# Altium schematic sheet Proximity_Sensors.SchDoc(Proximity_Sensors)
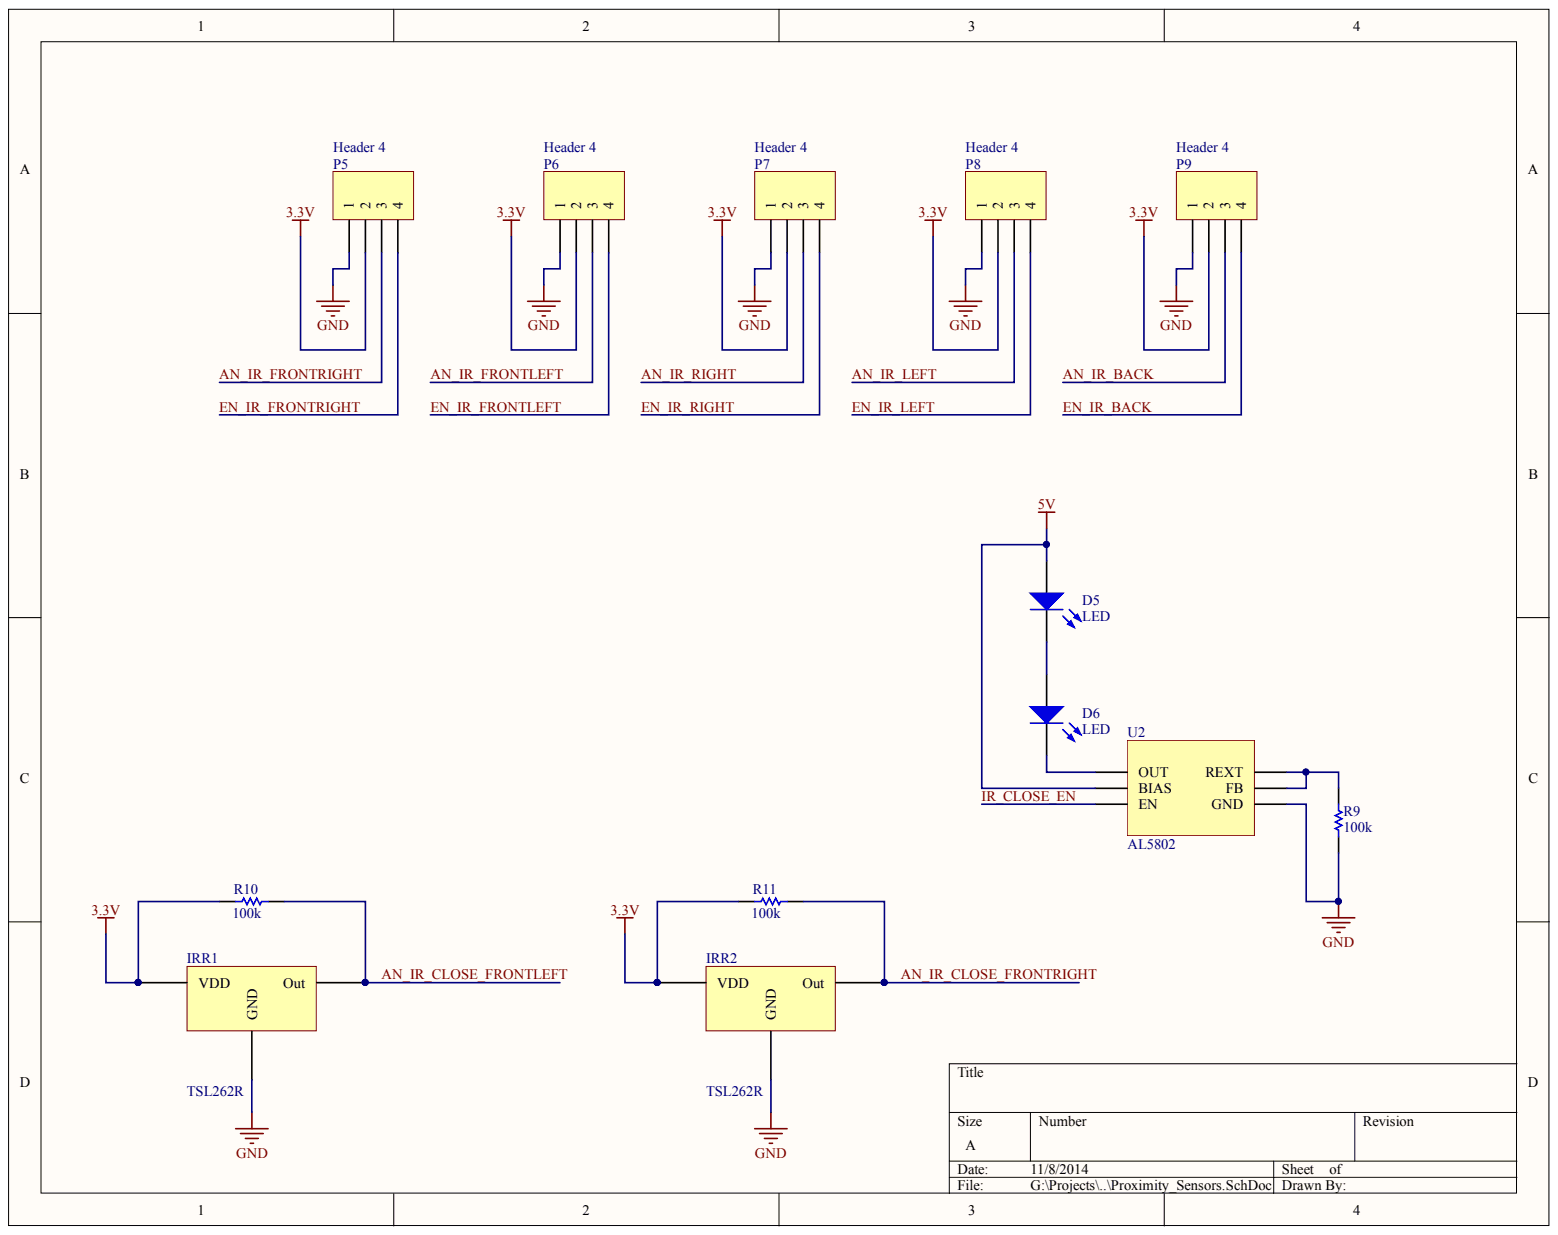
[diagram p0: Proximity_Sensors.SchDoc]

## Parts
D5  LED  [LED-Bent]
D6  LED  [LED-Bent]
IRR1  TSL262R  [TSL262R]
IRR2  TSL262R  [TSL262R]
P5  Header 4  [HDR1X4]
P6  Header 4  [HDR1X4]
P7  Header 4  [HDR1X4]
P8  Header 4  [HDR1X4]
P9  Header 4  [HDR1X4]
R9  value 100k  [0805]  (hidden comment: Resistor)
R10  value 100k  [0805]  (hidden comment: Resistor)
R11  value 100k  [0805]  (hidden comment: Resistor)
U2  AL5802  [SOT26]

## Nets
3.3V: IRR1.1 IRR2.1 P5.2 P6.2 P7.2 P8.2 P9.2 R10.1 R11.1
5V: D5.1 U2.2(BIAS)
GND: IRR1.0 IRR2.0 P5.1 P6.1 P7.1 P8.1 P9.1 R9.1 U2.4(GND)
AN_IR_BACK: P9.3
AN_IR_CLOSE_FRONTLEFT: IRR1.2 R10.2
AN_IR_CLOSE_FRONTRIGHT: IRR2.2 R11.2
AN_IR_FRONTLEFT: P6.3
AN_IR_FRONTRIGHT: P5.3
AN_IR_LEFT: P8.3
AN_IR_RIGHT: P7.3
EN_IR_BACK: P9.4
EN_IR_FRONTLEFT: P6.4
EN_IR_FRONTRIGHT: P5.4
EN_IR_LEFT: P8.4
EN_IR_RIGHT: P7.4
IR_CLOSE_EN: U2.3(EN)
NetD5_2: D5.2 D6.1
NetD6_2: D6.2 U2.1(OUT)
NetR9_2: R9.2 U2.5(FB) U2.6(REXT)

## Library data of the parts
D5 D6 = LED: Manufacturer: Vishay; Manufacturer Part Number: TSAL6100; Vendor Part Number: 751-1203-ND; Vendor: Digikey; Component Kind: Standard; Description: LED; LatestRevisionDate: 16-Mar-2013; LatestRevisionNote: Created; Library Name: passives.SchLib; PackageDescription: 5mm LED; 2 Leads; PackageReference: LED; Published: 16-Mar-2013; Publisher: Anthony Merlino
P5-P9 = Header 4: Header, 4-Pin; Component Kind: Standard; LatestRevisionDate: 17-Jul-2002; LatestRevisionNote: Re-released for DXP Platform.; Library Name: Miscellaneous Connectors.IntLib; Signal Integrity: Connector; Publisher: Altium Limited
IRR1 IRR2 = TSL262R: Component Kind: Standard; Library Name: Devices.SchLib
R9-R11 = Resistor: Component Kind: Standard; Description: Resistor; LatestRevisionDate: 17-Jul-2002; LatestRevisionNote: Re-released for DXP Platform.; Library Name: passives.SchLib; PackageReference: AXIAL-0.3; Published: 8-Jun-2000; Publisher: Altium Limited
U2 = AL5802: Component Kind: Standard; Library Name: Devices.SchLib
